# Altium schematic sheet P02-DC_JACK.SchDoc
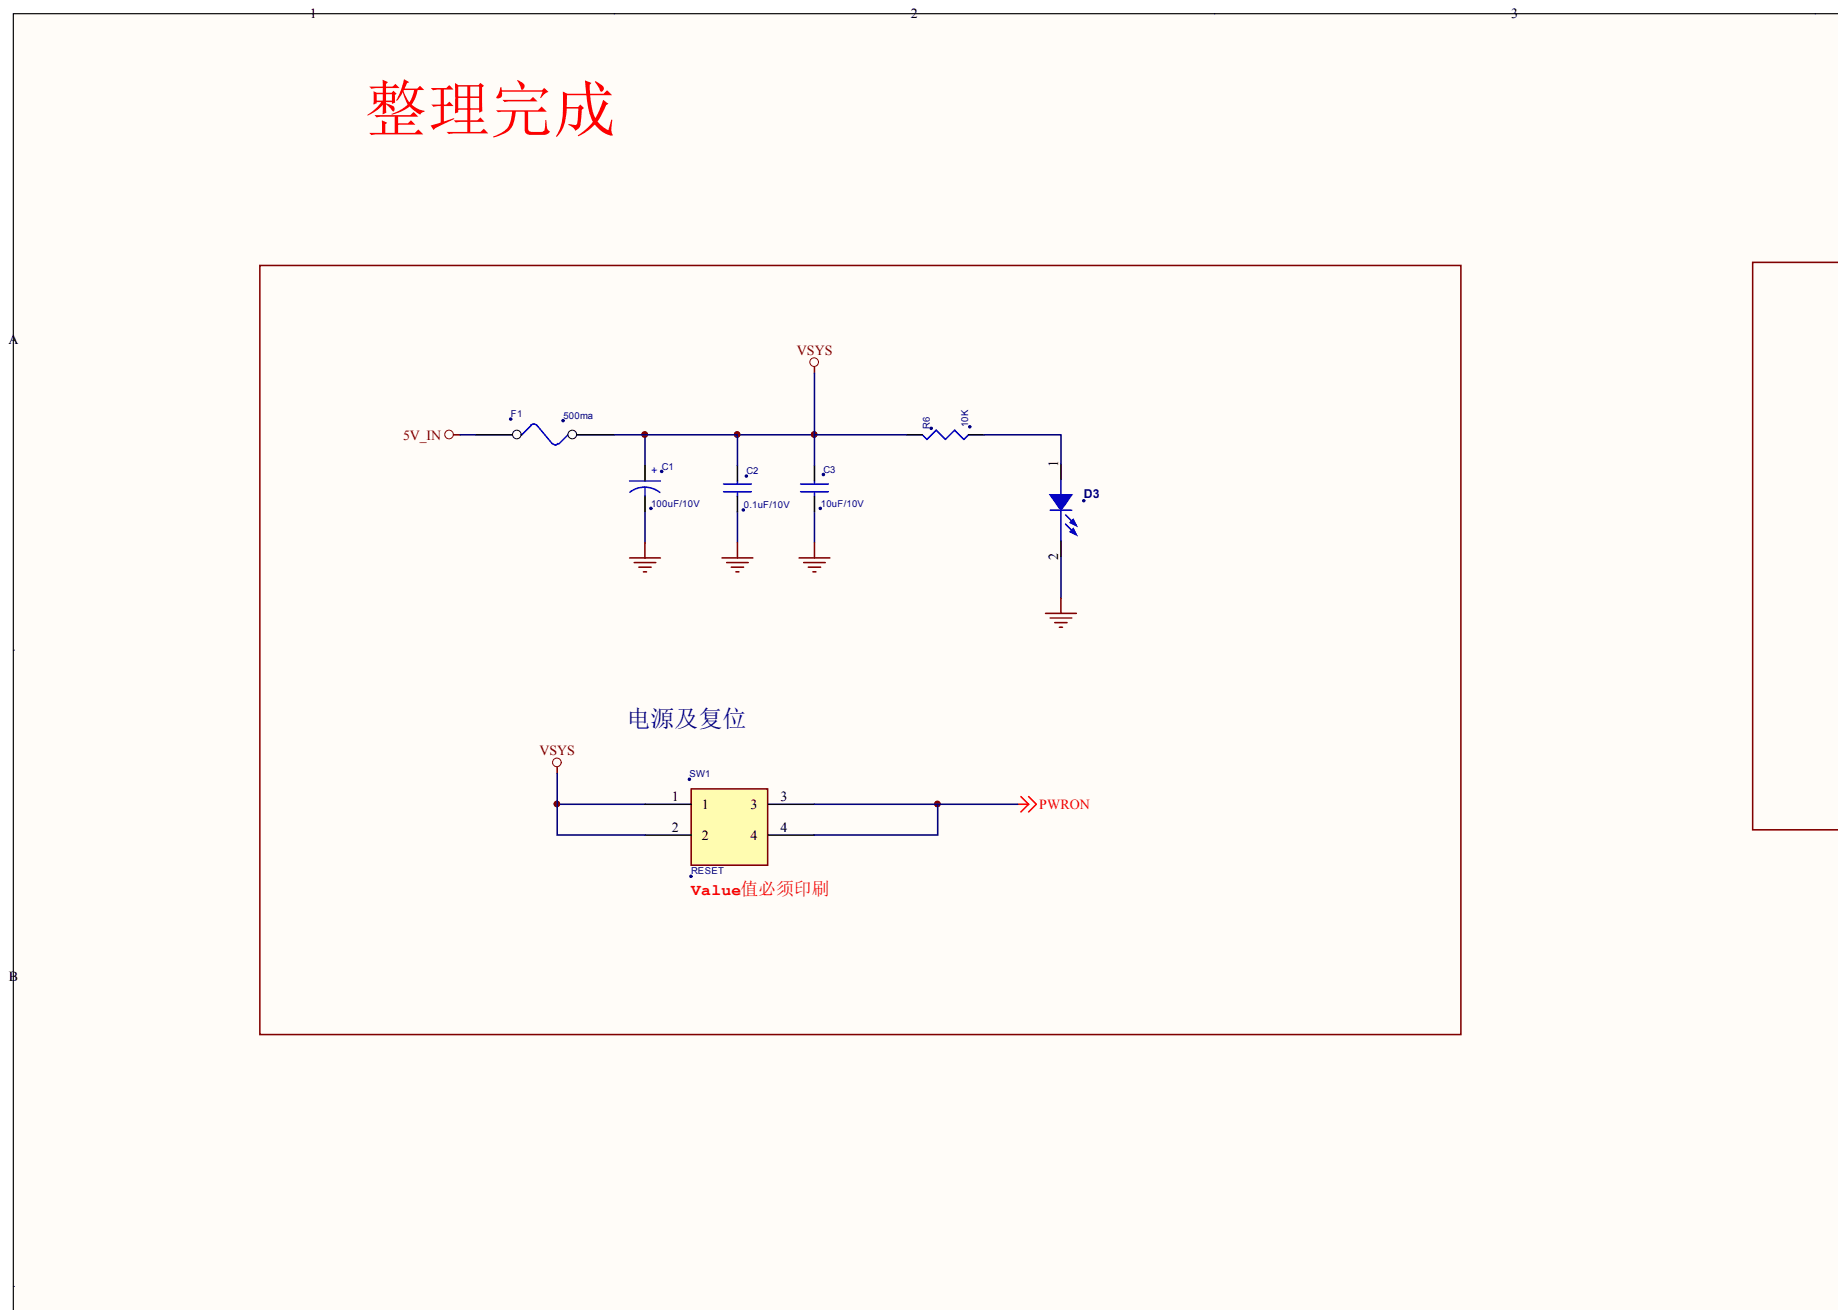
[diagram p0: P02-DC_JACK.SchDoc, top left]
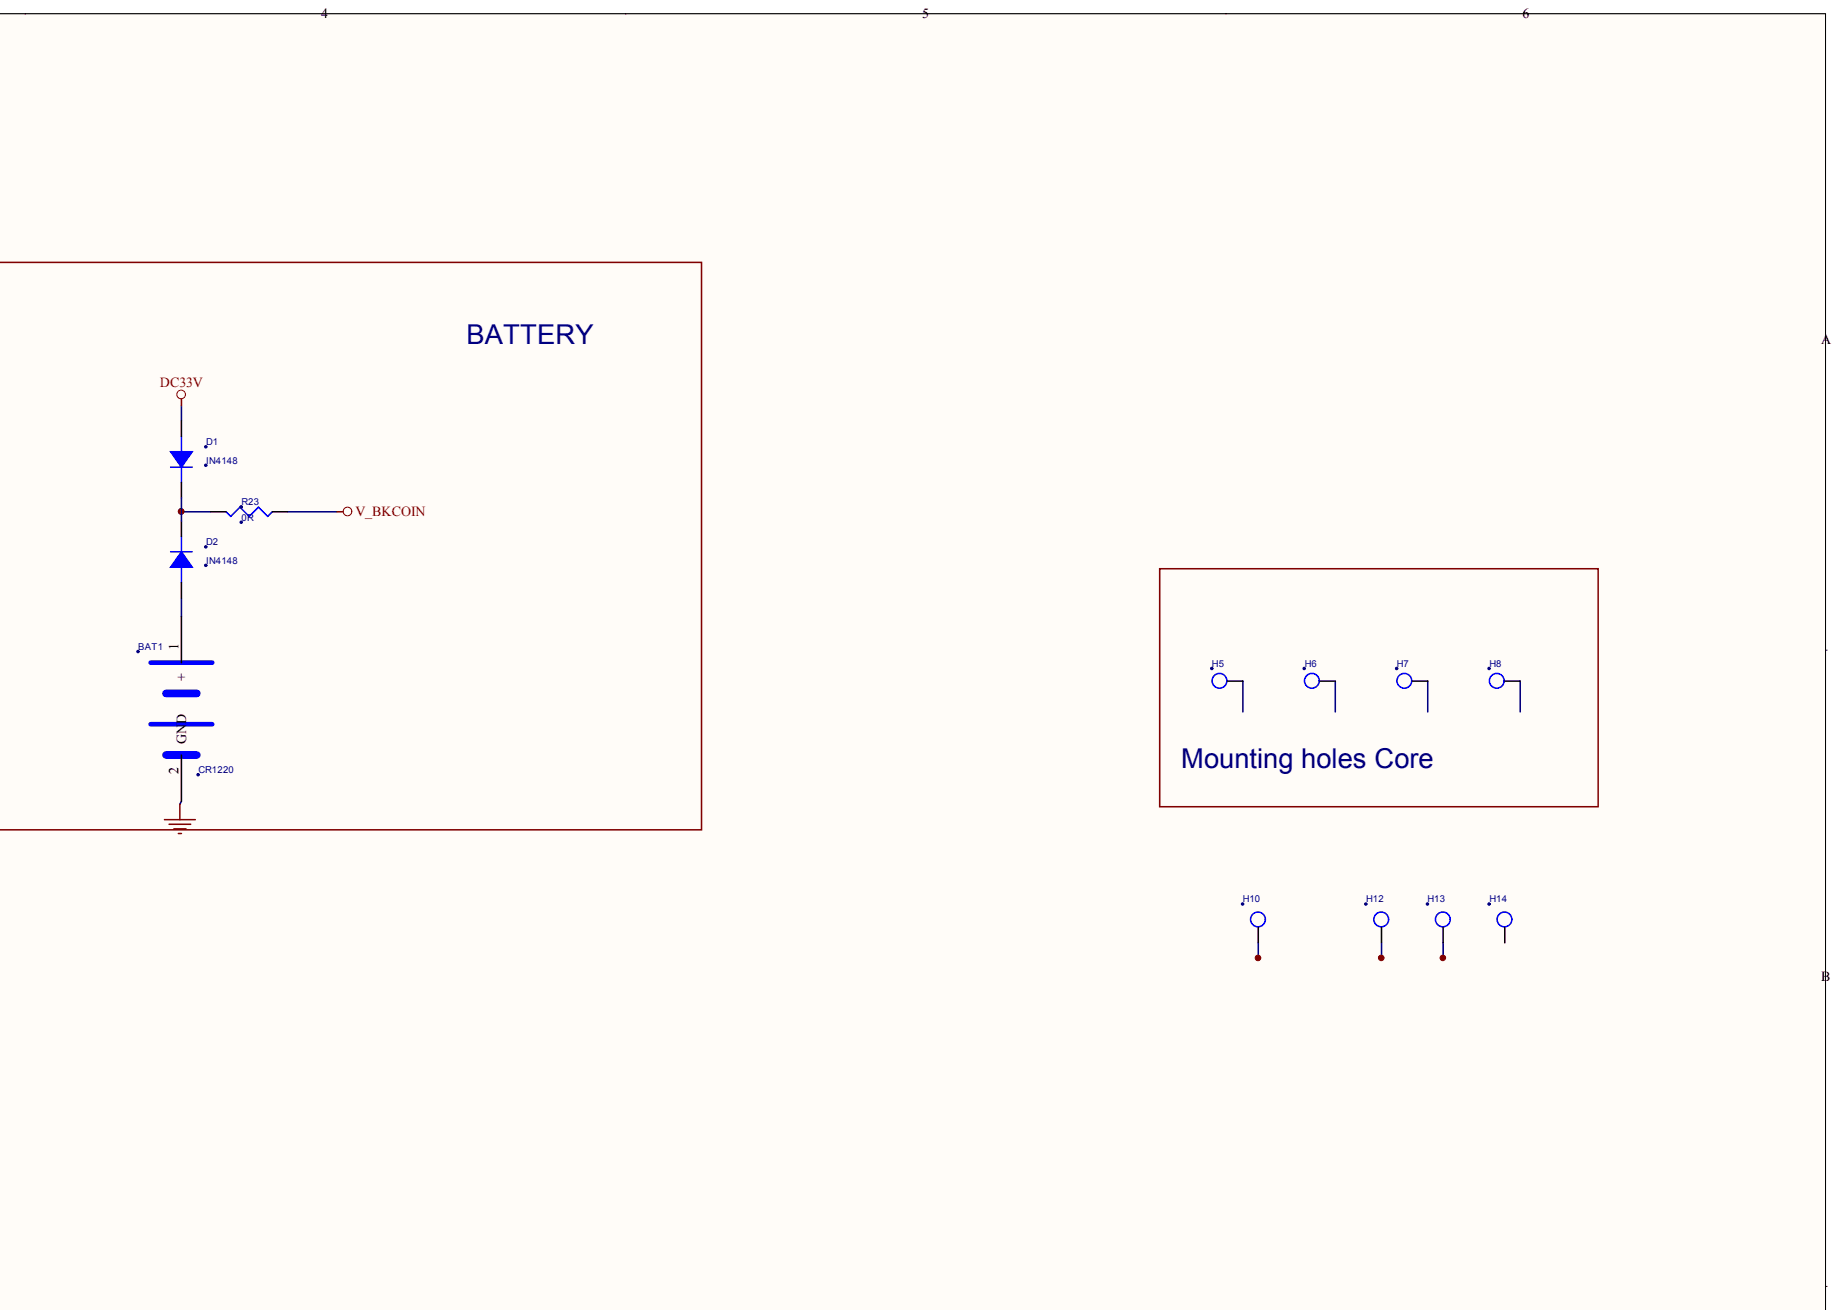
[diagram p1: P02-DC_JACK.SchDoc, top right]
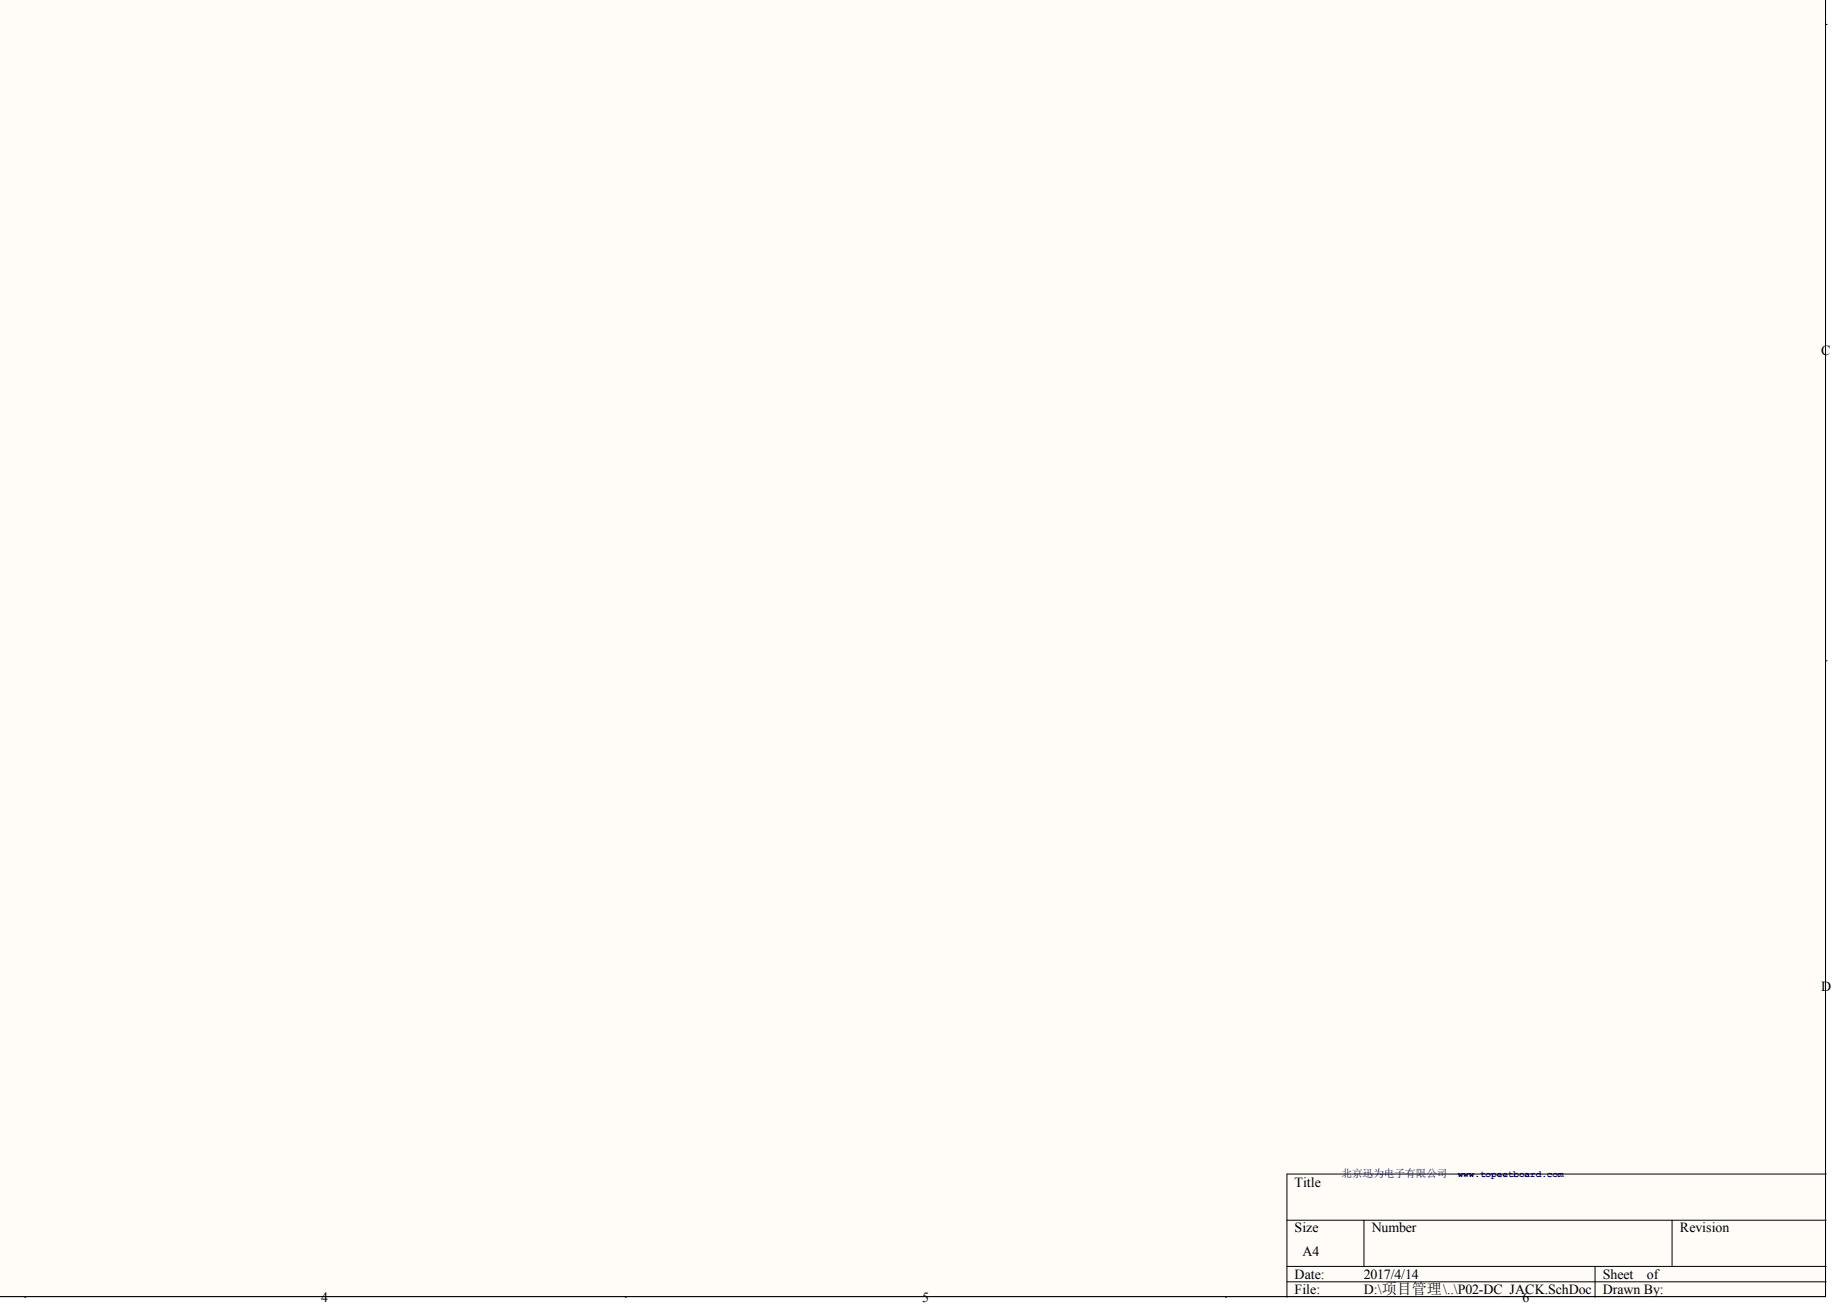
[diagram p2: P02-DC_JACK.SchDoc, bottom right]

## Parts
BAT1  CR1220
C1  100uF/10V
C2  0.1uF/10V
C3  10uF/10V
D1  IN4148
D2  IN4148
D3  LED-Red (SMD 2012)  (value not drawn)
F1  500ma
H5  TP_P0_0.8_D0  (value not drawn)
H6  TP_P0_0.8_D0  (value not drawn)
H7  TP_P0_0.8_D0  (value not drawn)
H8  TP_P0_0.8_D0  (value not drawn)
H10  TP_P0_0.8_D0  (value not drawn)
H12  TP_P0_0.8_D0  (value not drawn)
H13  TP_P0_0.8_D0  (value not drawn)
H14  TP_P0_0.8_D0  (value not drawn)
R6  10K
R23  0R
SW1  RESET

## Nets
5V_IN: F1.1
DC33V: D1.1
GND: BAT1.2 C1.2 C2.2 C3.2 D3.2
PWRON: SW1.3 SW1.4
V_BKCOIN: R23.1
VSYS: C1.1 C2.1 C3.1 F1.2 R6.2 SW1.1 SW1.2
NetBAT1_1: BAT1.1 D2.1
NetD1_2: D1.2 D2.2 R23.2
NetD3_1: D3.1 R6.1

Not connected: H5 1; H6 1; H7 1; H8 1; H10 1; H12 1; H13 1; H14 1
